# Altium schematic sheet Schematic.SchDoc(Schematic)
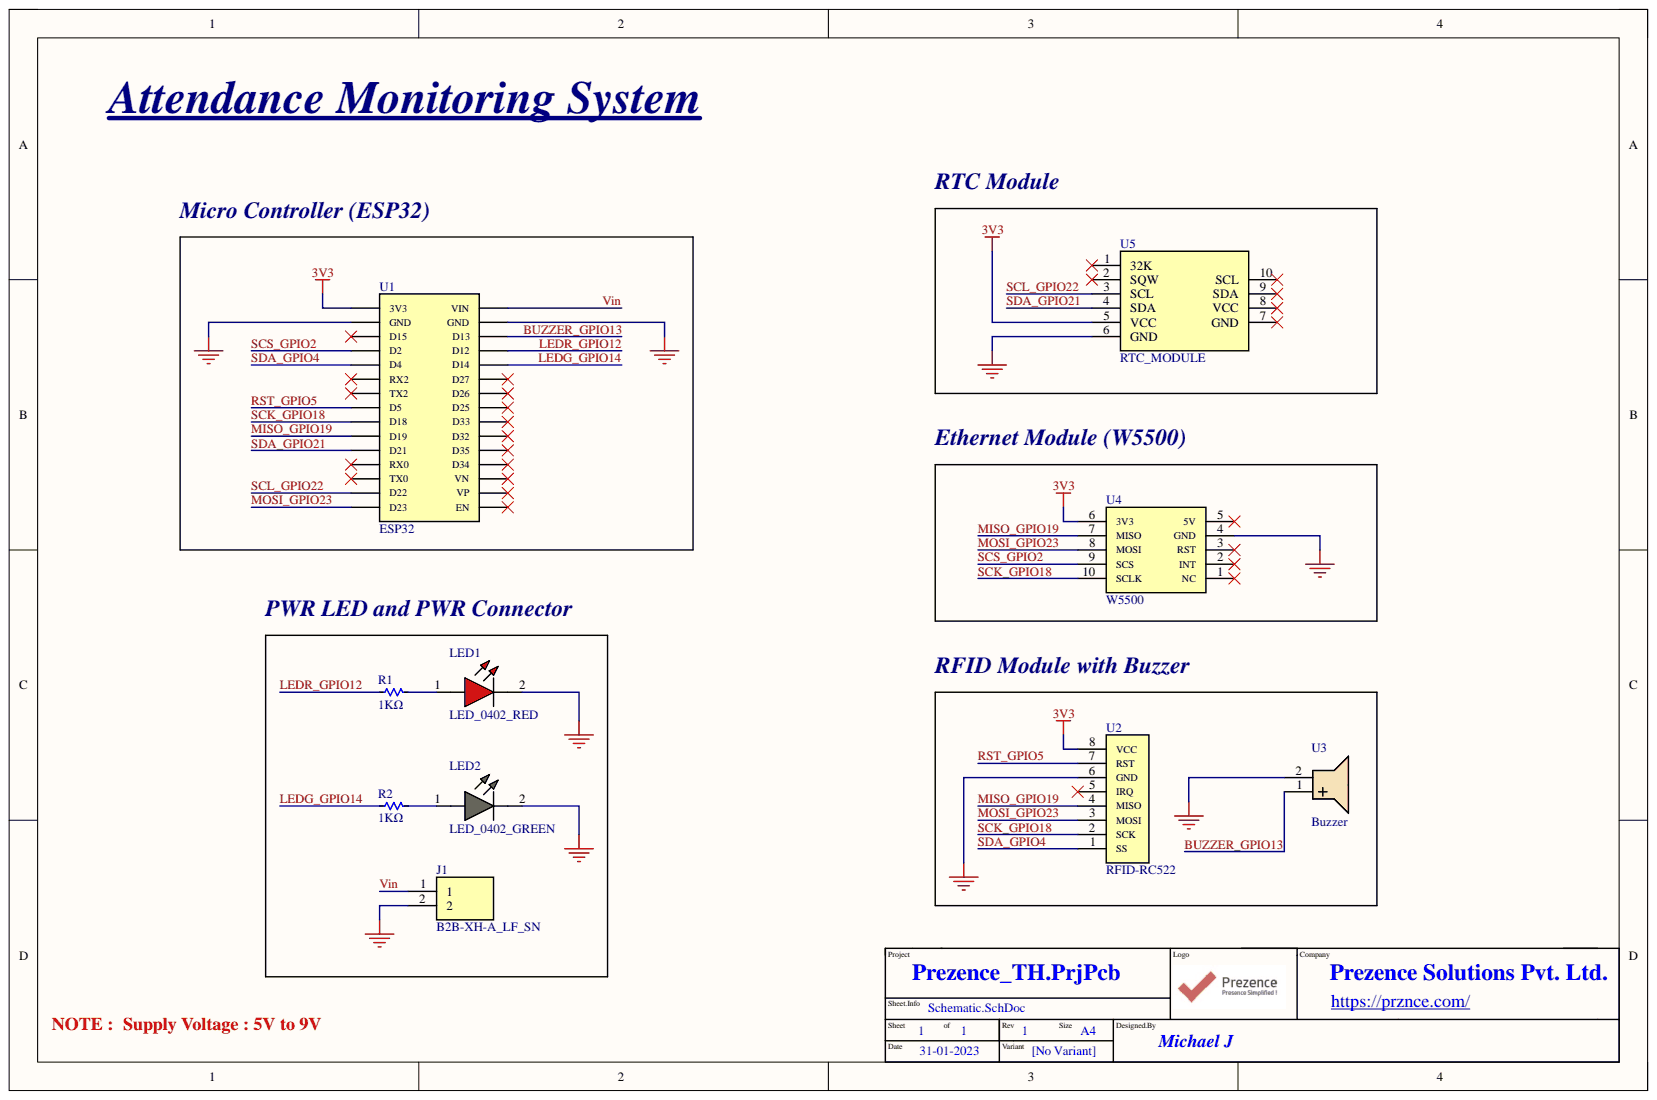
[diagram p0: Schematic.SchDoc]

## Parts
J1  B2B-XH-A_LF_SN  [2.0_POWER_CONNECTOR]
LED1  LED_0402_RED  [LED_RED]
LED2  LED_0402_GREEN  [LED_GREEN]
R1  1KΩ  [1k]
R2  1KΩ  [1k]
U1  ESP32  [ESP32_SMD]
U2  RFID-RC522  [RFID_RC522]
U3  Buzzer  [Buzzer]
U4  W5500  [W5500_ETHERNET_MODULE]
U5  RTC_MODULE  [DS3231_RCT_MODULE]

## Nets
3V3: U1.1(3V3) U2.8(VCC) U4.6(3V3) U5.5(VCC)
GND: J1.2 LED1.2 LED2.2 U1.2(GND) U1.29(GND) U2.6(GND) U3.2 U4.4(GND) U5.6(GND)
BUZZER_GPIO13: U1.28(D13) U3.1
LEDG_GPIO14: R2.1 U1.26(D14)
LEDR_GPIO12: R1.1 U1.27(D12)
MISO_GPIO19: U1.10(D19) U2.4(MISO) U4.7(MISO)
MOSI_GPIO23: U1.15(D23) U2.3(MOSI) U4.8(MOSI)
RST_GPIO5: U1.8(D5) U2.7(RST)
SCK_GPIO18: U1.9(D18) U2.2(SCK) U4.10(SCLK)
SCL_GPIO22: U1.14(D22) U5.3(SCL)
SCS_GPIO2: U1.4(D2) U4.9(SCS)
SDA_GPIO4: U1.5(D4) U2.1(SS)
SDA_GPIO21: U1.11(D21) U5.4(SDA)
Vin: J1.1 U1.30(VIN)
NetLED1_1: LED1.1 R1.2
NetLED2_1: LED2.1 R2.2

Not connected: U1 3(D15) 6(RX2) 7(TX2) 12(RX0) 13(TX0) 16(EN) 17(VP) 18(VN) 19(D34) 20(D35) 21(D32) 22(D33) 23(D25) 24(D26) 25(D27); U2 5(IRQ); U4 1(NC) 2(INT) 3(RST) 5(5V); U5 1(32K) 2(SQW) 7(GND) 8(VCC) 9(SDA) 10(SCL)

## Library data of the parts
J1 = B2B-XH-A_LF__SN_: Connector; Manufacturer_Name: JST (JAPAN SOLDERLESS TERMINALS); Manufacturer_Part_Number: B2B-XH-A(LF)(SN); Arrow Part Number: B2B-XH-A(LF)(SN); Arrow Price/Stock: https://www.arrow.com/en/products/b2b-xh-a-lf-sn/jst-manufacturing?region=europe; Component Kind: Standard; Datasheet Link: http://www.jst-mfg.com/product/pdf/eng/eXH.pdf; Height: 7mm; Library Name: Schlib.SchLib
LED1 = LED_0402_RED: LED SMD 0402 Red; Component Kind: Standard; Library Name: Schlib.SchLib
LED2 = LED_0402_GREEN: LED SMD 0402 Green; Manufacturer_Name: Kingbright; Manufacturer_Part_Number: APHHS1005LZGCK-V; Arrow Part Number: APHHS1005LZGCK-V; Arrow Price/Stock: https://www.arrow.com/en/products/aphhs1005lzgck-v/kingbright; Component Kind: Standard; Datasheet Link: https://www.kingbrightusa.com/images/catalog/SPEC/APHHS1005LZGCK-V.pdf; Height: 0.6mm; Library Name: Schlib.SchLib; Mouser Part Number: N/A; Mouser Price/Stock: https://www.mouser.co.uk/ProductDetail/Kingbright/APHHS1005LZGCK-V?qs=HXFqYaX1Q2zaZg1%252BPZjK%2FQ%3D%3D
R1 R2 = 1KΩ: RES 1KΩ OHM 1/4W 5% AXIAL; Manufacturer: Yageo; Manufacturer Part Number: CFR-25JB-52-2K2; Max Power Dissipation: 250mW; Resistance: 2.2kR; Temperature Coefficient: 500ppm/°C; Case/Package: Axial; Category: Through-Hole Resistors; Component Kind: Standard; ComponentLink1Description: http://www.yageo.com/NewPortal/yageodocoutput?fileName=/pdf/throughhole/Yageo_LR_CFR_2013.pdf&src-supplier=Digi-Key; ComponentLink1URL: http://www.yageo.com/NewPortal/yageodocoutput?fileName=/pdf/throughhole/Yageo_LR_CFR_2013.pdf&src-supplier=Digi-Key; Lead Free: Lead Free; Length: 0.248inch; Library Name: Schlib.SchLib; Max Operating Temperature: 155°C; Min Operating Temperature: -55°C; Mount: Through Hole; Number of Terminations: 2; Package Quantity: 200; Packaging: Bulk; Pricing 1: 1=0.10000, 10=0.06700, 25=0.04640, 50=0.03600, 100=0.02580, 250=0.02008, 500=0.01596, 1000=0.01133, 5000=0.00876 (USD); Resistor Type: Carbon Film; RoHS: Yes; RoHS Compliant: Yes; Series: Carbon Film; Stock 1: 57847; Supplier 1: Digi-Key; Supplier Part Number 1: 2.2KQBK-ND; Tolerance: 5%
U3 = Buzzer: 4mm 2mm Externally Driven 4mm 70dB@3V,10cm Magnetic 2V~4V 3V 4000Hz SMD Buzzers ROHS; Part number: GSC4417YA-16R4000; Component Kind: Standard; Library Name: Schlib.SchLib
U4 = W5500: ETHERNET MODULE; Component Kind: Standard; Library Name: Schlib.SchLib
U1 = ESP32: Component Kind: Standard; Description: ESP32; Library Name: Schlib.SchLib
U2 = RFID-RC522: Component Kind: Standard; Description: RFID-RC522; Library Name: Schlib.SchLib
U5 = RTC_MODULE: Component Kind: Standard; Description: RTC_MODULE; Library Name: Schlib.SchLib
